# Altium schematic sheet digtal_nw.SchDoc
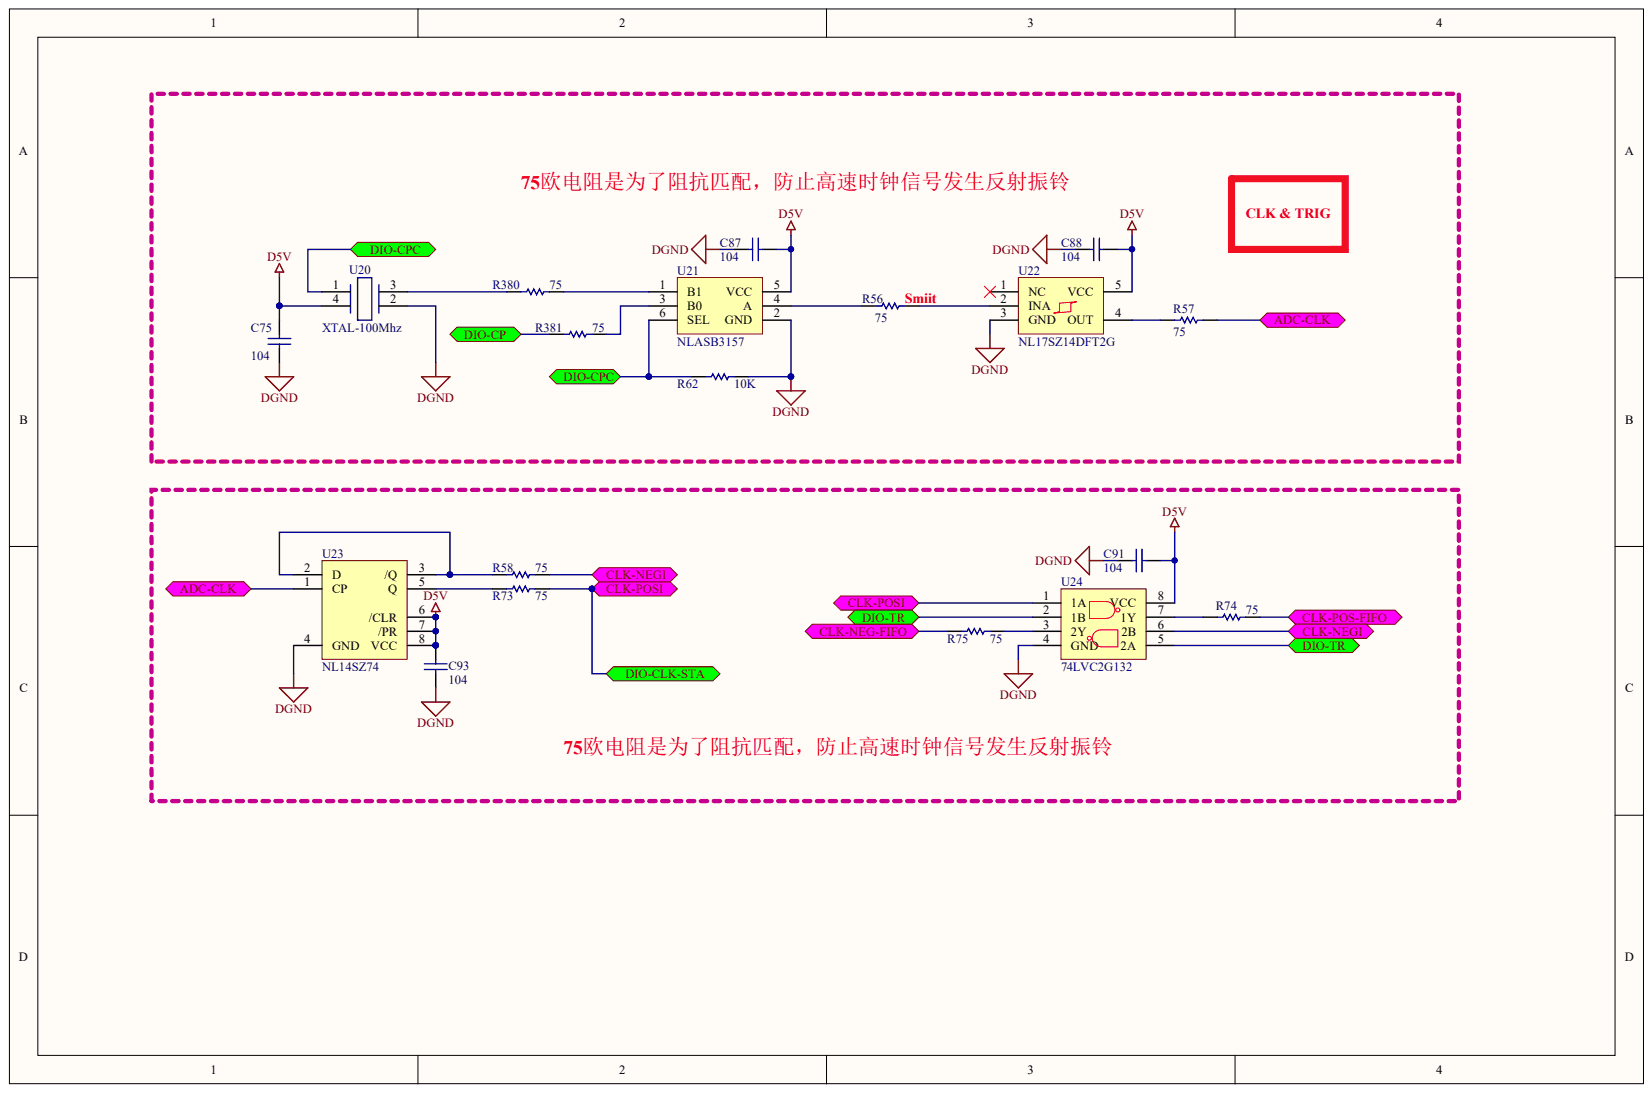
[diagram p0: digtal_nw.SchDoc]

## Parts
C75  C104  (value not drawn)
C87  C104  (value not drawn)
C88  C104  (value not drawn)
C91  C104  (value not drawn)
C93  C104  (value not drawn)
R56  75
R57  75
R58  75
R62  10K
R73  75
R74  75
R75  75
R380  75
R381  75
U20  XTAL-100Mhz
U21  NLASB3157
U22  NL17SZ14DFT2G
U23  NL14SZ74
U24  74LVC2G132

## Ports
ADC-CLK, CLK-NEG-FIFO, CLK-NEGI, CLK-POS-FIFO, CLK-POSI, DIO-CLK-STA, DIO-CP, DIO-CPC, DIO-TR

## Nets
D5V: C75.1 C87.1 C88.1 C91.1 C93.1 U20.4 U21.5(VCC) U22.5(VCC) U23.6(/CLR) U23.7(/PR) U23.8(VCC) U24.8(VCC)
DGND: C75.2 C87.2 C88.2 C91.2 C93.2 R62.2 U20.2 U21.2(GND) U22.3(GND) U23.4(GND) U24.4(GND)
ADC-CLK: R57.1 U23.1(CP) ; port ADC-CLK
CLK-NEG-FIFO: R75.2 ; port CLK-NEG-FIFO
CLK-NEGI: R58.1 U24.6(2B) ; port CLK-NEGI
CLK-POS-FIFO: R74.1 ; port CLK-POS-FIFO
CLK-POSI: R73.1 U24.1(1A) ; port CLK-POSI, port DIO-CLK-STA
DIO-CP: R381.2 ; port DIO-CP
DIO-CPC: R62.1 U20.1 U21.6(SEL) ; port DIO-CPC
DIO-TR: U24.2(1B) U24.5(2A) ; port DIO-TR
NetR56_1: R56.1 U22.2(INA)
NetR56_2: R56.2 U21.4(A)
NetR57_2: R57.2 U22.4(OUT)
NetR58_2: R58.2 U23.2(D) U23.3(/Q)
NetR73_2: R73.2 U23.5(Q)
NetR74_2: R74.2 U24.7(1Y)
NetR75_1: R75.1 U24.3(2Y)
NetR380_1: R380.1 U21.1(B1)
NetR380_2: R380.2 U20.3
NetR381_1: R381.1 U21.3(B0)

Not connected: U22 1(NC)

## Library data of the parts
C75 C87 C88 C91 C93 R56-R58 R62 R73-R75 R380 R381 U20-U24 = (no symbol name)
  C75 C87 C88 C91 C93: : 104
  R56-R58 R73-R75 R380 R381: : 75
  R62: : 10K
